# Altium schematic sheet Output.SchDoc
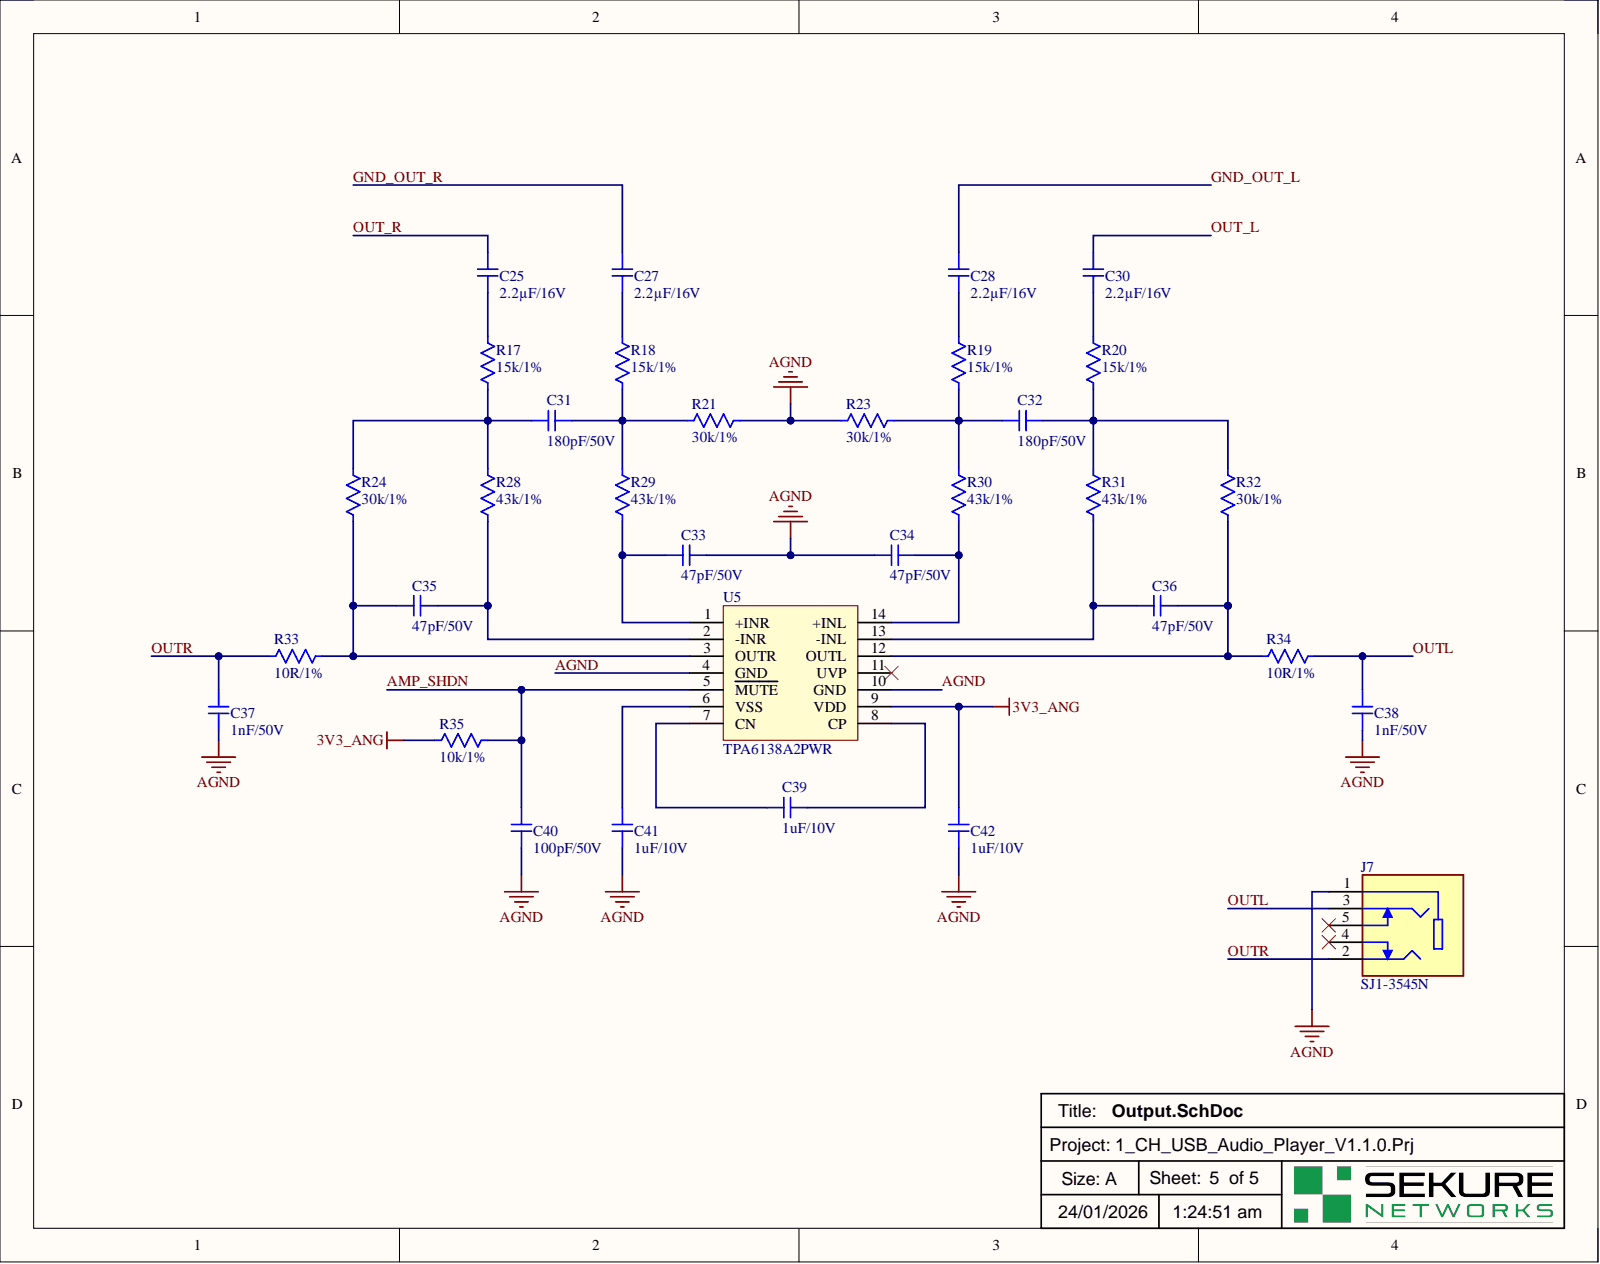
[diagram p0: Output.SchDoc]

## Parts
C25  value 2.2µF/16V  [CAP 0603]  (hidden comment: Ceramic Capacitor)
C27  value 2.2µF/16V  [CAP 0603]  (hidden comment: Ceramic Capacitor)
C28  value 2.2µF/16V  [CAP 0603]  (hidden comment: Ceramic Capacitor)
C30  value 2.2µF/16V  [CAP 0603]  (hidden comment: Ceramic Capacitor)
C31  value 180pF/50V  [CAP 0603]  (hidden comment: Ceramic Capacitor)
C32  value 180pF/50V  [CAP 0603]  (hidden comment: Ceramic Capacitor)
C33  value 47pF/50V  [CAP 0603]  (hidden comment: Ceramic Capacitor)
C34  value 47pF/50V  [CAP 0603]  (hidden comment: Ceramic Capacitor)
C35  value 47pF/50V  [CAP 0603]  (hidden comment: Ceramic Capacitor)
C36  value 47pF/50V  [CAP 0603]  (hidden comment: Ceramic Capacitor)
C37  value 1nF/50V  [CAP 0603]  (hidden comment: Ceramic Capacitor)
C38  value 1nF/50V  [CAP 0603]  (hidden comment: Ceramic Capacitor)
C39  value 1uF/10V  [CAP 0603]  (hidden comment: Ceramic Capacitor)
C40  value 100pF/50V  [CAP 0603]  (hidden comment: Ceramic Capacitor)
C41  value 1uF/10V  [CAP 0603]  (hidden comment: Ceramic Capacitor)
C42  value 1uF/10V  [CAP 0603]  (hidden comment: Ceramic Capacitor)
J7  value SJ1-3545N  [SJ1-3545N]  (hidden comment: Connector)
R17  value 15k/1%  [RES 0603]  (hidden comment: Resistor)
R18  value 15k/1%  [RES 0603]  (hidden comment: Resistor)
R19  value 15k/1%  [RES 0603]  (hidden comment: Resistor)
R20  value 15k/1%  [RES 0603]  (hidden comment: Resistor)
R21  value 30k/1%  [RES 0603]  (hidden comment: Resistor)
R23  value 30k/1%  [RES 0603]  (hidden comment: Resistor)
R24  value 30k/1%  [RES 0603]  (hidden comment: Resistor)
R28  value 43k/1%  [RES 0603]  (hidden comment: Resistor)
R29  value 43k/1%  [RES 0603]  (hidden comment: Resistor)
R30  value 43k/1%  [RES 0603]  (hidden comment: Resistor)
R31  value 43k/1%  [RES 0603]  (hidden comment: Resistor)
R32  value 30k/1%  [RES 0603]  (hidden comment: Resistor)
R33  value 10R/1%  [RES 0603]  (hidden comment: Resistor)
R34  value 10R/1%  [RES 0603]  (hidden comment: Resistor)
R35  value 10k/1%  [RES 0603]  (hidden comment: Resistor)
U5  value TPA6138A2PWR  [14-TSSOP]  (hidden comment: IC)

## Nets
3V3_ANG: C42.2 R35.2 U5.9(VDD)
AGND: C33.1 C34.2 C37.1 C38.1 C40.1 C41.1 C42.1 J7.1 R21.1 R23.2 U5.4(GND) U5.10(GND)
AMP_SHDN: C40.2 R35.1 U5.5(MUTE)
GND_OUT_L: C28.2
GND_OUT_R: C27.2
OUT_L: C30.2
OUT_R: C25.2
OUTL: C38.2 J7.3 R34.2
OUTR: C37.2 J7.2 R33.1
NetC25_1: C25.1 R17.1
NetC27_1: C27.1 R18.1
NetC28_1: C28.1 R19.1
NetC30_1: C30.1 R20.1
NetC31_1: C31.1 R17.2 R24.1 R28.1
NetC31_2: C31.2 R18.2 R21.2 R29.1
NetC32_1: C32.1 R20.2 R31.1 R32.1
NetC32_2: C32.2 R19.2 R23.1 R30.1
NetC33_2: C33.2 R29.2 U5.1(+INR)
NetC34_1: C34.1 R30.2 U5.14(+INL)
NetC35_1: C35.1 R28.2 U5.2(-INR)
NetC35_2: C35.2 R24.2 R33.2 U5.3(OUTR)
NetC36_1: C36.1 R32.2 R34.1 U5.12(OUTL)
NetC36_2: C36.2 R31.2 U5.13(-INL)
NetC39_1: C39.1 U5.7(CN)
NetC39_2: C39.2 U5.8(CP)
NetC41_2: C41.2 U5.6(VSS)

Not connected: J7 4 5; U5 11(UVP)

## Library data of the parts
C25 C27 C28 C30 = CL10A225KO8NNNC: 16V 2.2uF X5R ±10% 0603 Multilayer Ceramic Capacitors MLCC - SMD/SMT ROHS; Manufacturer: Samsung Electro-Mechanics; Case Code (Imperial): 0603; Case Code (Metric): 1608; Case/Package: 0603; Category: Ceramic Capacitors; China RoHS: Compliant; Component Kind: Standard; ComponentLink1Description: https://datasheet.octopart.com:443/CL10A225KO8NNNC-Samsung-Electro-Mechanics-datasheet-136031763.pdf?src-supplier=IHS; ComponentLink1URL: https://datasheet.octopart.com:443/CL10A225KO8NNNC-Samsung-Electro-Mechanics-datasheet-136031763.pdf?src-supplier=IHS; ComponentLink2Description: https://datasheet.octopart.com/CL10A225KO8NNNC-Samsung-Electro-Mechanics-datasheet-8324245.pdf; ComponentLink2URL: https://datasheet.octopart.com/CL10A225KO8NNNC-Samsung-Electro-Mechanics-datasheet-8324245.pdf; ComponentLink3Description: https://datasheet.octopart.com/CL10A225KO8NNNC-Samsung-Electro-Mechanics-datasheet-97040617.pdf; ComponentLink3URL: https://datasheet.octopart.com/CL10A225KO8NNNC-Samsung-Electro-Mechanics-datasheet-97040617.pdf; ComponentLink4Description: https://datasheet.octopart.com/General-Multilayer-Ceramic-Capacitors-Samsung-datasheet-family-111.pdf; ComponentLink4URL: https://datasheet.octopart.com/General-Multilayer-Ceramic-Capacitors-Samsung-datasheet-family-111.pdf; ComponentLink5Description: https://datasheet.octopart.com:443/CL10A225KO8NNNC-Samsung-Electro-Mechanics-datasheet-110326828.pdf?src-supplier=Future+Electronics; ComponentLink5URL: https://datasheet.octopart.com:443/CL10A225KO8NNNC-Samsung-Electro-Mechanics-datasheet-110326828.pdf?src-supplier=Future+Electronics; ComponentLink6Description: https://datasheet.octopart.com:443/CL10A225KO8NNNC-Samsung-Electro-Mechanics-datasheet-81461797.pdf?src-supplier=Future+Electronics; ComponentLink6URL: https://datasheet.octopart.com:443/CL10A225KO8NNNC-Samsung-Electro-Mechanics-datasheet-81461797.pdf?src-supplier=Future+Electronics; ComponentLink7Description: https://datasheet.octopart.com:443/CL10A225KO8NNNC-Samsung-datasheet-48647245.pdf?src-supplier=Future+Electronics; ComponentLink7URL: https://datasheet.octopart.com:443/CL10A225KO8NNNC-Samsung-datasheet-48647245.pdf?src-supplier=Future+Electronics; ComponentLink8Description: https://datasheet.octopart.com:443/CL10A225KO8NNNC-Samsung-datasheet-26589124.pdf?src-supplier=Future+Electronics; ComponentLink8URL: https://datasheet.octopart.com:443/CL10A225KO8NNNC-Samsung-datasheet-26589124.pdf?src-supplier=Future+Electronics; ComponentLink9Description: https://datasheet.octopart.com:443/CL10A225KO8NNNC-Samsung-Electro-Mechanics-datasheet-149156492.pdf?src-supplier=Future+Electronics; ComponentLink9URL: https://datasheet.octopart.com:443/CL10A225KO8NNNC-Samsung-Electro-Mechanics-datasheet-149156492.pdf?src-supplier=Future+Electronics; ComponentLink10Description: https://datasheet.octopart.com:443/CL10A225KO8NNNC-Samsung-Electro-Mechanics-datasheet-11768396.pdf?src-supplier=Arrow+Electronics; ComponentLink10URL: https://datasheet.octopart.com:443/CL10A225KO8NNNC-Samsung-Electro-Mechanics-datasheet-11768396.pdf?src-supplier=Arrow+Electronics; ComponentLink11Description: https://datasheet.octopart.com:443/CL10A225KO8NNNC-Samsung-datasheet-59220082.pdf?src-supplier=TME; ComponentLink11URL: https://datasheet.octopart.com:443/CL10A225KO8NNNC-Samsung-datasheet-59220082.pdf?src-supplier=TME; ComponentLink12Description: http://sigma.octopart.com:80/rohs_certificate/General-Multilayer-Ceramic-Capacitors-Samsung-family-110.pdf?src-supplier=Compliance+Statements; ComponentLink12URL: http://sigma.octopart.com:80/rohs_certificate/General-Multilayer-Ceramic-Capacitors-Samsung-family-110.pdf?src-supplier=Compliance+Statements; ComponentLink13Description: http://sigma.octopart.com:80/rohs_statement/General-Multilayer-Ceramic-Capacitors-Samsung-family-132.pdf?src-supplier=Compliance+Statements; ComponentLink13URL: http://sigma.octopart.com:80/rohs_statement/General-Multilayer-Ceramic-Capacitors-Samsung-family-132.pdf?src-supplier=Compliance+Statements; ComponentLink14Description: http://sigma.octopart.com:80/reach_statement/General-Multilayer-Ceramic-Capacitors-Samsung-family-133.pdf?src-supplier=Compliance+Statements; ComponentLink14URL: http://sigma.octopart.com:80/reach_statement/General-Multilayer-Ceramic-Capacitors-Samsung-family-133.pdf?src-supplier=Compliance+Statements; Contact Plating: Tin; Depth: 800µm; Dielectric: X5R; Dielectric Material: Ceramic; DigiKey: 1276-1040-1-ND; Height: 900µm; Lead Free: Lead Free; Length: 1.6mm; Library Name: Ceramic Capacitors.IntLib; Lifecycle Status: Production; Material: Ceramic; Max Operating Temperature: 85°C; Min Operating Temperature: -55°C; Mounting Technology: Surface Mount; Number of Terminals: 2; P/N: CL10A225KO8NNNC; Pricing: 1=0.08, 10=0.009, 50=0.0088 (USD); Radiation Hardening: No; REACH SVHC: Yes; RoHS: Compliant; Schedule B: 8532240020, 8532240020|8532240020|8532240020|8532240020|8532240020|8532240020|8532240020|8532240020|8532240020|8532240020|8532240020|8532240020|8532240020|8532240020|8532240020|8532240020|8532240020|8532240020|8532240020|8532240020|8532240020|8532240020|8532240020|85; Stock: 10221; Supplier: DigiKey; Thickness: 889µm; Tolerance: 10%; Voltage: 16V; Voltage Rating: 16V; Voltage Rating (DC): 16V; Width: 800µm
C31 C32 = CL10C181JB81PND: Cap Ceramic 180pF 50V C0G 5% Pad SMD 0603 125C Automotive T/R; Manufacturer: Samsung Electro-Mechanics; Temperature Coefficient: 30ppm/°C; Case Code (Imperial): 0603; Case Code (Metric): 1608; Case/Package: 0603; Category: Ceramic Capacitors; China RoHS: Compliant; Component Kind: Standard; ComponentLink1Description: https://datasheet.octopart.com:443/CL10C181JB81PND-Samsung-Electro-Mechanics-datasheet-176142735.pdf?src-supplier=IHS; ComponentLink1URL: https://datasheet.octopart.com:443/CL10C181JB81PND-Samsung-Electro-Mechanics-datasheet-176142735.pdf?src-supplier=IHS; ComponentLink2Description: https://datasheet.octopart.com:443/CL10C181JB81PND-Samsung-Electro-Mechanics-datasheet-141347352.pdf?src-supplier=Samsung+SCT; ComponentLink2URL: https://datasheet.octopart.com:443/CL10C181JB81PND-Samsung-Electro-Mechanics-datasheet-141347352.pdf?src-supplier=Samsung+SCT; Depth: 800µm; Dielectric: C0G; Dielectric Material: Ceramic; DigiKey: 1276-6452-1-ND; Height: 900µm; Length: 1.6mm; Library Name: Ceramic Capacitors.IntLib; Lifecycle Status: Production; Material: Ceramic; Max Operating Temperature: 125°C; Min Operating Temperature: -55°C; Mounting Technology: Surface Mount; Number of Terminals: 2; P/N: CL10C181JB81PND; Pricing: 1=0.1, 10=0.044, 50=0.03, 100=0.0258, 500=0.01866, 1000=0.01649, 2500=0.01421, 5000=0.01284 (USD); Radiation Hardening: No; Ratings: AEC-Q200; REACH SVHC: Yes; RoHS: Compliant; Schedule B: 8532240020; Stock: 10810; Supplier: DigiKey; Thickness: 889µm; Tolerance: 5%; Voltage: 50V; Voltage Rating: 50V; Voltage Rating (DC): 50V; Width: 787.4µm
C33-C36 = CL10C470JB8NNNC: Multilayer Ceramic Capacitor, 47 pF, 50 V, ± 5%, C0G (NP0), 0603 [1608 Metric]; Manufacturer: Samsung Electro-Mechanics; Temperature Coefficient: 300ppm/°C; Voltage: 50V; Case Code (Imperial): 0603; Case Code (Metric): 1608; Case/Package: 0603; Category: Ceramic Capacitors; China RoHS: Compliant; Component Kind: Standard; ComponentLink1Description: https://datasheet.octopart.com:443/CL10C470JB8NNNC-Samsung-Electro-Mechanics-datasheet-136482436.pdf?src-supplier=Farnell; ComponentLink1URL: https://datasheet.octopart.com:443/CL10C470JB8NNNC-Samsung-Electro-Mechanics-datasheet-136482436.pdf?src-supplier=Farnell; ComponentLink2Description: https://datasheet.octopart.com/CL10C470JB8NNNC-Samsung-Electro-Mechanics-datasheet-8324245.pdf; ComponentLink2URL: https://datasheet.octopart.com/CL10C470JB8NNNC-Samsung-Electro-Mechanics-datasheet-8324245.pdf; ComponentLink3Description: https://datasheet.octopart.com/CL10C470JB8NNNC-Samsung-Electro-Mechanics-datasheet-97040617.pdf; ComponentLink3URL: https://datasheet.octopart.com/CL10C470JB8NNNC-Samsung-Electro-Mechanics-datasheet-97040617.pdf; ComponentLink4Description: https://datasheet.octopart.com:443/CL10C470JB8NNNC-Samsung-Electro-Mechanics-datasheet-110226376.pdf?src-supplier=Future+Electronics; ComponentLink4URL: https://datasheet.octopart.com:443/CL10C470JB8NNNC-Samsung-Electro-Mechanics-datasheet-110226376.pdf?src-supplier=Future+Electronics; ComponentLink5Description: https://datasheet.octopart.com:443/CL10C470JB8NNNC-Samsung-Electro-Mechanics-datasheet-81462059.pdf?src-supplier=Future+Electronics; ComponentLink5URL: https://datasheet.octopart.com:443/CL10C470JB8NNNC-Samsung-Electro-Mechanics-datasheet-81462059.pdf?src-supplier=Future+Electronics; ComponentLink6Description: https://datasheet.octopart.com:443/CL10C470JB8NNNC-Samsung-datasheet-48647380.pdf?src-supplier=Future+Electronics; ComponentLink6URL: https://datasheet.octopart.com:443/CL10C470JB8NNNC-Samsung-datasheet-48647380.pdf?src-supplier=Future+Electronics; ComponentLink7Description: https://datasheet.octopart.com:443/CL10C470JB8NNNC-Samsung-datasheet-26669179.pdf?src-supplier=Future+Electronics; ComponentLink7URL: https://datasheet.octopart.com:443/CL10C470JB8NNNC-Samsung-datasheet-26669179.pdf?src-supplier=Future+Electronics; ComponentLink8Description: https://datasheet.octopart.com:443/CL10C470JB8NNNC-Samsung-Electro-Mechanics-datasheet-149136926.pdf?src-supplier=Future+Electronics; ComponentLink8URL: https://datasheet.octopart.com:443/CL10C470JB8NNNC-Samsung-Electro-Mechanics-datasheet-149136926.pdf?src-supplier=Future+Electronics; ComponentLink9Description: https://datasheet.octopart.com:443/CL10C470JB8NNNC-Samsung-datasheet-8326407.pdf?src-supplier=TME; ComponentLink9URL: https://datasheet.octopart.com:443/CL10C470JB8NNNC-Samsung-datasheet-8326407.pdf?src-supplier=TME; ComponentLink10Description: https://datasheet.octopart.com:443/CL10C470JB8NNNC-Samsung-Electro-Mechanics-datasheet-11541288.pdf?src-supplier=Arrow+Electronics; ComponentLink10URL: https://datasheet.octopart.com:443/CL10C470JB8NNNC-Samsung-Electro-Mechanics-datasheet-11541288.pdf?src-supplier=Arrow+Electronics; Depth: 800µm; Dielectric: C0G; Dielectric Material: Ceramic; DigiKey: 1276-1037-1-ND; Height: 900µm; Lead Free: Lead Free; Length: 1.6mm; Library Name: Ceramic Capacitors.IntLib; Lifecycle Status: Production; Material: Ceramic; Max Operating Temperature: 125°C; Min Operating Temperature: -55°C; Mounting Technology: Surface Mount; Number of Terminals: 2; P/N: CL10C470JB8NNNC; Pricing: 1=0.1, 10=0.034, 50=0.0228, 100=0.0195, 500=0.01394, 1000=0.01225 (USD); Radiation Hardening: No; REACH SVHC: Yes; RoHS: Compliant; Schedule B: 8532240020, 8532240020|8532240020, 8532240020|8532240020|8532240020, 8532240020|8532240020|8532240020|8532240020; Stock: 1779120; Supplier: DigiKey; Thickness: 889µm; Tolerance: 5%; Voltage Rating: 50V; Voltage Rating (DC): 50V; Width: 800µm
C37 C38 = CL10B102KB8NNNC: Ceramic Capacitor, Multilayer, Ceramic, 50V, 10% +Tol, 10% -Tol, X7R, +-15% TC, 1nF, Surface Mount, 0603 inch; Manufacturer: Samsung Electro-Mechanics; Case Code (Imperial): 0603; Case Code (Metric): 1608; Case/Package: 0603; Category: Ceramic Capacitors; China RoHS: Compliant; Component Kind: Standard; ComponentLink1Description: https://datasheet.octopart.com:443/CL10B102KB8NNNC-Samsung-Electro-Mechanics-datasheet-137699842.pdf?src-supplier=IHS; ComponentLink1URL: https://datasheet.octopart.com:443/CL10B102KB8NNNC-Samsung-Electro-Mechanics-datasheet-137699842.pdf?src-supplier=IHS; ComponentLink2Description: https://datasheet.octopart.com/CL10B102KB8NNNC-Samsung-Electro-Mechanics-datasheet-8324245.pdf; ComponentLink2URL: https://datasheet.octopart.com/CL10B102KB8NNNC-Samsung-Electro-Mechanics-datasheet-8324245.pdf; ComponentLink3Description: https://datasheet.octopart.com/CL10B102KB8NNNC-Samsung-Electro-Mechanics-datasheet-97040617.pdf; ComponentLink3URL: https://datasheet.octopart.com/CL10B102KB8NNNC-Samsung-Electro-Mechanics-datasheet-97040617.pdf; ComponentLink4Description: https://datasheet.octopart.com/General-Multilayer-Ceramic-Capacitors-Samsung-datasheet-family-111.pdf; ComponentLink4URL: https://datasheet.octopart.com/General-Multilayer-Ceramic-Capacitors-Samsung-datasheet-family-111.pdf; ComponentLink5Description: https://datasheet.octopart.com:443/CL10B102KB8NNNC-Samsung-Electro-Mechanics-datasheet-127092097.pdf?src-supplier=Future+Electronics; ComponentLink5URL: https://datasheet.octopart.com:443/CL10B102KB8NNNC-Samsung-Electro-Mechanics-datasheet-127092097.pdf?src-supplier=Future+Electronics; ComponentLink6Description: https://datasheet.octopart.com:443/CL10B102KB8NNNC-Samsung-Electro-Mechanics-datasheet-81461821.pdf?src-supplier=Future+Electronics; ComponentLink6URL: https://datasheet.octopart.com:443/CL10B102KB8NNNC-Samsung-Electro-Mechanics-datasheet-81461821.pdf?src-supplier=Future+Electronics; ComponentLink7Description: https://datasheet.octopart.com:443/CL10B102KB8NNNC-Samsung-datasheet-44382877.pdf?src-supplier=Future+Electronics; ComponentLink7URL: https://datasheet.octopart.com:443/CL10B102KB8NNNC-Samsung-datasheet-44382877.pdf?src-supplier=Future+Electronics; ComponentLink8Description: https://datasheet.octopart.com:443/CL10B102KB8NNNC-Samsung-datasheet-26589141.pdf?src-supplier=Future+Electronics; ComponentLink8URL: https://datasheet.octopart.com:443/CL10B102KB8NNNC-Samsung-datasheet-26589141.pdf?src-supplier=Future+Electronics; ComponentLink9Description: https://datasheet.octopart.com:443/CL10B102KB8NNNC-Samsung-Electro-Mechanics-datasheet-149158821.pdf?src-supplier=Future+Electronics; ComponentLink9URL: https://datasheet.octopart.com:443/CL10B102KB8NNNC-Samsung-Electro-Mechanics-datasheet-149158821.pdf?src-supplier=Future+Electronics; ComponentLink10Description: https://datasheet.octopart.com:443/CL10B102KB8NNNC-Samsung-datasheet-8326407.pdf?src-supplier=TME; ComponentLink10URL: https://datasheet.octopart.com:443/CL10B102KB8NNNC-Samsung-datasheet-8326407.pdf?src-supplier=TME; ComponentLink11Description: http://sigma.octopart.com:80/rohs_certificate/General-Multilayer-Ceramic-Capacitors-Samsung-family-110.pdf?src-supplier=Compliance+Statements; ComponentLink11URL: http://sigma.octopart.com:80/rohs_certificate/General-Multilayer-Ceramic-Capacitors-Samsung-family-110.pdf?src-supplier=Compliance+Statements; ComponentLink12Description: http://sigma.octopart.com:80/rohs_statement/General-Multilayer-Ceramic-Capacitors-Samsung-family-132.pdf?src-supplier=Compliance+Statements; ComponentLink12URL: http://sigma.octopart.com:80/rohs_statement/General-Multilayer-Ceramic-Capacitors-Samsung-family-132.pdf?src-supplier=Compliance+Statements; ComponentLink13Description: http://sigma.octopart.com:80/reach_statement/General-Multilayer-Ceramic-Capacitors-Samsung-family-133.pdf?src-supplier=Compliance+Statements; ComponentLink13URL: http://sigma.octopart.com:80/reach_statement/General-Multilayer-Ceramic-Capacitors-Samsung-family-133.pdf?src-supplier=Compliance+Statements; Contact Plating: Tin; Depth: 800µm; Dielectric: X7R; Dielectric Material: Ceramic; DigiKey: 1276-1018-1-ND; Height: 900µm; Lead Free: Lead Free; Length: 1.6mm; Library Name: Ceramic Capacitors.IntLib; Lifecycle Status: Production; Material: Ceramic; Max Operating Temperature: 125°C; Min Operating Temperature: -55°C; Mounting Technology: Surface Mount; Number of Terminals: 2; P/N: CL10B102KB8NNNC; Pricing: 1=0.08, 10=0.004 (USD); Radiation Hardening: No; REACH SVHC: Yes; RoHS: Compliant; Schedule B: 8532240020, 8532240020|8532240020, 8532240020|8532240020|8532240020, 8532240020|8532240020|8532240020|8532240020; Stock: 785625; Supplier: DigiKey; Thickness: 889µm; Tolerance: 10%; Voltage: 50V; Voltage Rating: 50V; Voltage Rating (DC): 50V; Width: 800µm
C39 C41 C42 = CL10B105KP8NNNC: Multilayer Ceramic Capacitor, 1 uF, 10 V, ± 10%, X7R, 0603 [1608 Metric]; Manufacturer: Samsung; Material: 4241ConductorMaterial; Voltage Rating: 10V; Case Code (Imperial): 0603; Case Code (Metric): 1608; Case/Package: 0603; Category: Ceramic Capacitors; Component Kind: Standard; Construction: Flat; Depth: 0.8mm; Dielectric: X7R; DigiKey: 1276-1946-1-ND; Height: 0.8mm; Lead Free: Lead Free; Length: 1.6mm; Library Name: Ceramic Capacitors.IntLib; Max Operating Temperature: 125°C; Min Operating Temperature: -55°C; Mounting Technology: Surface Mount; P/N: CL10B105KP8NNNC; Package Quantity: 1; Packaging: Tape and Reel; Pricing: 1=0.1, 10=0.026, 50=0.0146, 100=0.0114, 500=0.00858, 1000=0.00674 (USD); Radiation Hardening: No; RoHS: Yes; RoHS Compliant: Yes; Series: CL; Stock: 7012743; Supplier: Digikey; Thickness: 0.035inch; Tolerance: 10%; Voltage Rating (DC): 10V; Width: 0.031inch
C40 = CL10C101JB8NNNC: Ceramic Capacitor, Multilayer, Ceramic, 50V, 5% +Tol, 5% -Tol, C0G, 0+-30ppm/Celcius TC, 100pF, Surface Mount, 0603...; Manufacturer: Samsung Electro-Mechanics; Number of Channels: 1; Temperature Coefficient: 30ppm/°C; Case Code (Imperial): 0603; Case Code (Metric): 1608; Case/Package: 0603; Category: Ceramic Capacitors; China RoHS: Compliant; Component Kind: Standard; ComponentLink1Description: https://datasheet.octopart.com:443/CL10C101JB8NNNC-Samsung-Electro-Mechanics-datasheet-136482390.pdf?src-supplier=IHS; ComponentLink1URL: https://datasheet.octopart.com:443/CL10C101JB8NNNC-Samsung-Electro-Mechanics-datasheet-136482390.pdf?src-supplier=IHS; ComponentLink2Description: https://datasheet.octopart.com/CL10C101JB8NNNC-Samsung-Electro-Mechanics-datasheet-8324245.pdf; ComponentLink2URL: https://datasheet.octopart.com/CL10C101JB8NNNC-Samsung-Electro-Mechanics-datasheet-8324245.pdf; ComponentLink3Description: https://datasheet.octopart.com/CL10C101JB8NNNC-Samsung-Electro-Mechanics-datasheet-97040617.pdf; ComponentLink3URL: https://datasheet.octopart.com/CL10C101JB8NNNC-Samsung-Electro-Mechanics-datasheet-97040617.pdf; ComponentLink4Description: https://datasheet.octopart.com/General-Multilayer-Ceramic-Capacitors-Samsung-datasheet-family-111.pdf; ComponentLink4URL: https://datasheet.octopart.com/General-Multilayer-Ceramic-Capacitors-Samsung-datasheet-family-111.pdf; ComponentLink5Description: https://datasheet.octopart.com:443/CL10C101JB8NNNC-Samsung-Electro-Mechanics-datasheet-110326842.pdf?src-supplier=Future+Electronics; ComponentLink5URL: https://datasheet.octopart.com:443/CL10C101JB8NNNC-Samsung-Electro-Mechanics-datasheet-110326842.pdf?src-supplier=Future+Electronics; ComponentLink6Description: https://datasheet.octopart.com:443/CL10C101JB8NNNC-Samsung-Electro-Mechanics-datasheet-81461969.pdf?src-supplier=Future+Electronics; ComponentLink6URL: https://datasheet.octopart.com:443/CL10C101JB8NNNC-Samsung-Electro-Mechanics-datasheet-81461969.pdf?src-supplier=Future+Electronics; ComponentLink7Description: https://datasheet.octopart.com:443/CL10C101JB8NNNC-Samsung-datasheet-12521739.pdf?src-supplier=Future+Electronics; ComponentLink7URL: https://datasheet.octopart.com:443/CL10C101JB8NNNC-Samsung-datasheet-12521739.pdf?src-supplier=Future+Electronics; ComponentLink8Description: https://datasheet.octopart.com:443/CL10C101JB8NNNC-Samsung-datasheet-26669111.pdf?src-supplier=Future+Electronics; ComponentLink8URL: https://datasheet.octopart.com:443/CL10C101JB8NNNC-Samsung-datasheet-26669111.pdf?src-supplier=Future+Electronics; ComponentLink9Description: https://datasheet.octopart.com:443/CL10C101JB8NNNC-Samsung-datasheet-8328827.pdf?src-supplier=Future+Electronics; ComponentLink9URL: https://datasheet.octopart.com:443/CL10C101JB8NNNC-Samsung-datasheet-8328827.pdf?src-supplier=Future+Electronics; ComponentLink10Description: https://datasheet.octopart.com:443/CL10C101JB8NNNC-Samsung-Electro-Mechanics-datasheet-149154131.pdf?src-supplier=Future+Electronics; ComponentLink10URL: https://datasheet.octopart.com:443/CL10C101JB8NNNC-Samsung-Electro-Mechanics-datasheet-149154131.pdf?src-supplier=Future+Electronics; ComponentLink11Description: https://datasheet.octopart.com:443/CL10C101JB8NNNC-Samsung-datasheet-8326407.pdf?src-supplier=TME; ComponentLink11URL: https://datasheet.octopart.com:443/CL10C101JB8NNNC-Samsung-datasheet-8326407.pdf?src-supplier=TME; ComponentLink12Description: http://sigma.octopart.com:80/rohs_certificate/General-Multilayer-Ceramic-Capacitors-Samsung-family-110.pdf?src-supplier=Compliance+Statements; ComponentLink12URL: http://sigma.octopart.com:80/rohs_certificate/General-Multilayer-Ceramic-Capacitors-Samsung-family-110.pdf?src-supplier=Compliance+Statements; ComponentLink13Description: http://sigma.octopart.com:80/rohs_statement/General-Multilayer-Ceramic-Capacitors-Samsung-family-132.pdf?src-supplier=Compliance+Statements; ComponentLink13URL: http://sigma.octopart.com:80/rohs_statement/General-Multilayer-Ceramic-Capacitors-Samsung-family-132.pdf?src-supplier=Compliance+Statements; ComponentLink14Description: http://sigma.octopart.com:80/reach_statement/General-Multilayer-Ceramic-Capacitors-Samsung-family-133.pdf?src-supplier=Compliance+Statements; ComponentLink14URL: http://sigma.octopart.com:80/reach_statement/General-Multilayer-Ceramic-Capacitors-Samsung-family-133.pdf?src-supplier=Compliance+Statements; Contact Plating: Tin; Depth: 800µm; Dielectric: C0G; Dielectric Material: Ceramic; DigiKey: 1276-1008-1-ND; Height: 900µm; Lead Free: Lead Free; Length: 1.6mm; Library Name: Ceramic Capacitors.IntLib; Lifecycle Status: Production; Material: Ceramic; Max Operating Temperature: 125°C; Min Operating Temperature: -55°C; Mounting Technology: Surface Mount; Number of Terminals: 2; P/N: CL10C101JB8NNNC; Pricing: 1=0.08, 10=0.005, 50=0.0044, 100=0.004, 500=0.00374 (USD); Radiation Hardening: No; REACH SVHC: Yes; RoHS: Compliant; Schedule B: 8532240020, 8532240020|8532240020, 8532240020|8532240020|8532240020, 8532240020|8532240020|8532240020|8532240020; Stock: 1051756; Supplier: DigiKey; Thickness: 889µm; Tolerance: 5%; Voltage: 50V; Voltage Rating: 50V; Voltage Rating (DC): 50V; Width: 800µm
J7 = SJ1-3545N: 3.5 mm, Stereo, Right Angle, Through Hole, Kinked Pins, Audio Jack Connector; Manufacturer: CUI Devices; Body Color: Black; Body Material: Brass; Gender: Female; Shielding: Unshielded; Category: Audio / Video Connectors; Component Kind: Standard; ComponentLink1Description: https://datasheet.octopart.com/SJ1-3545N-CUI-datasheet-23290.pdf?src-supplier=Digikey; ComponentLink1URL: https://datasheet.octopart.com/SJ1-3545N-CUI-datasheet-23290.pdf?src-supplier=Digikey; ComponentLink2Description: https://datasheet.octopart.com/SJ1-3545N-CUI-datasheet-15696630.pdf?src-supplier=Future+Electronics; ComponentLink2URL: https://datasheet.octopart.com/SJ1-3545N-CUI-datasheet-15696630.pdf?src-supplier=Future+Electronics; ComponentLink3Description: https://datasheet.octopart.com/SJ1-3545N-CUI-Devices-datasheet-175925702.pdf?src-supplier=Master+Electronics; ComponentLink3URL: https://datasheet.octopart.com/SJ1-3545N-CUI-Devices-datasheet-175925702.pdf?src-supplier=Master+Electronics; Contact Material: Brass; Contact Plating: Nickel,Tin; DigiKey: CP1-3545N-ND; Housing Material: PBT; Library Name: Connectors.IntLib; Max Operating Temperature: 85°C; Min Operating Temperature: -25°C; Mounting Technology: Through Hole; P/N: SJ1-3545N; Pricing: 1=1.22, 10=1.066, 25=1.0208, 50=0.9982, 100=0.9527, 250=0.862, 500=0.79876 (USD); RoHS: Yes; Series: SJ1; Stock: 39405; Supplier: Digikey; Termination: Kinked Pin
R17-R20 = ERJ-3EKF1502V: Res Thick Film 0603 15K Ohm 1% 1/10W ±100ppm/°C SMD Punched Carrier T/R; Manufacturer: Panasonic; Manufacturer Lifecycle Status: ACTIVE; Part Series: Precision Thick Film Chip Resistors; Max Power Dissipation: 100mW; Voltage Rating: 75V; Case Code (Imperial): 0603; Case/Package: 0603; Category: Chip SMD Resistors; China RoHS: Non-Compliant; Component Kind: Standard; ComponentLink1Description: https://datasheet.octopart.com:443/ERJ3EKF1502V-Panasonic-datasheet-182147282.pdf?src-supplier=IHS; ComponentLink1URL: https://datasheet.octopart.com:443/ERJ3EKF1502V-Panasonic-datasheet-182147282.pdf?src-supplier=IHS; ComponentLink2Description: https://datasheet.octopart.com:443/ERJ-3EKF1502V-Panasonic-datasheet-82288794.pdf?src-supplier=Panasonic; ComponentLink2URL: https://datasheet.octopart.com:443/ERJ-3EKF1502V-Panasonic-datasheet-82288794.pdf?src-supplier=Panasonic; ComponentLink3Description: https://datasheet.octopart.com:443/ERJ-3EKF1502V-Panasonic-datasheet-13266541.pdf?src-supplier=Newark; ComponentLink3URL: https://datasheet.octopart.com:443/ERJ-3EKF1502V-Panasonic-datasheet-13266541.pdf?src-supplier=Newark; ComponentLink4Description: https://datasheet.octopart.com:443/ERJ-3EKF1502V-Panasonic-datasheet-5313456.pdf?src-supplier=Newark; ComponentLink4URL: https://datasheet.octopart.com:443/ERJ-3EKF1502V-Panasonic-datasheet-5313456.pdf?src-supplier=Newark; ComponentLink5Description: https://datasheet.octopart.com:443/ERJ-3EKF1502V-Panasonic-datasheet-48891056.pdf?src-supplier=Newark; ComponentLink5URL: https://datasheet.octopart.com:443/ERJ-3EKF1502V-Panasonic-datasheet-48891056.pdf?src-supplier=Newark; ComponentLink6Description: https://datasheet.octopart.com:443/ERJ3EKF1502V-Panasonic-datasheet-168681906.pdf?src-supplier=Newark; ComponentLink6URL: https://datasheet.octopart.com:443/ERJ3EKF1502V-Panasonic-datasheet-168681906.pdf?src-supplier=Newark; ComponentLink7Description: https://datasheet.octopart.com:443/ERJ-3EKF1502V-Panasonic-datasheet-136608610.pdf?src-supplier=Burklin+Elektronik; ComponentLink7URL: https://datasheet.octopart.com:443/ERJ-3EKF1502V-Panasonic-datasheet-136608610.pdf?src-supplier=Burklin+Elektronik; ComponentLink8Description: https://datasheet.octopart.com:443/ERJ-3EKF1502V-Panasonic-datasheet-10025800.pdf?src-supplier=Future+Electronics; ComponentLink8URL: https://datasheet.octopart.com:443/ERJ-3EKF1502V-Panasonic-datasheet-10025800.pdf?src-supplier=Future+Electronics; ComponentLink9Description: https://datasheet.octopart.com:443/ERJ-3EKF1502V-Panasonic-datasheet-8853621.pdf?src-supplier=Future+Electronics; ComponentLink9URL: https://datasheet.octopart.com:443/ERJ-3EKF1502V-Panasonic-datasheet-8853621.pdf?src-supplier=Future+Electronics; ComponentLink10Description: https://datasheet.octopart.com:443/ERJ3EKF1502V-Panasonic-datasheet-164353789.pdf?src-supplier=Master+Electronics; ComponentLink10URL: https://datasheet.octopart.com:443/ERJ3EKF1502V-Panasonic-datasheet-164353789.pdf?src-supplier=Master+Electronics; ComponentLink11Description: https://datasheet.octopart.com:443/ERJ-3EKF1502V-Panasonic-datasheet-154766169.pdf?src-supplier=Master+Electronics; ComponentLink11URL: https://datasheet.octopart.com:443/ERJ-3EKF1502V-Panasonic-datasheet-154766169.pdf?src-supplier=Master+Electronics; ComponentLink12Description: https://datasheet.octopart.com:443/ERJ3EKF1502V-Panasonic-datasheet-138819429.pdf?src-supplier=TME; ComponentLink12URL: https://datasheet.octopart.com:443/ERJ3EKF1502V-Panasonic-datasheet-138819429.pdf?src-supplier=TME; ComponentLink13Description: https://datasheet.octopart.com/ERJ-3EKF1502V-Panasonic-datasheet-78375410.pdf; ComponentLink13URL: https://datasheet.octopart.com/ERJ-3EKF1502V-Panasonic-datasheet-78375410.pdf; ComponentLink14Description: https://datasheet.octopart.com:443/ERJ-3EKF1502V-Panasonic-datasheet-11542829.pdf?src-supplier=Arrow+Electronics; ComponentLink14URL: https://datasheet.octopart.com:443/ERJ-3EKF1502V-Panasonic-datasheet-11542829.pdf?src-supplier=Arrow+Electronics; ComponentLink15Description: https://datasheet.octopart.com:443/ERJ-3EKF1502V-Panasonic-datasheet-118621.pdf?src-supplier=DigiKey; ComponentLink15URL: https://datasheet.octopart.com:443/ERJ-3EKF1502V-Panasonic-datasheet-118621.pdf?src-supplier=DigiKey; ComponentLink16Description: https://datasheet.octopart.com:443/ERJ-3EKF1502V-Panasonic-datasheet-41391857.pdf?src-supplier=Electro+Sonic; ComponentLink16URL: https://datasheet.octopart.com:443/ERJ-3EKF1502V-Panasonic-datasheet-41391857.pdf?src-supplier=Electro+Sonic; Composition: Thick Film; Contact Plating: Tin; Depth: 800µm; DigiKey: P15.0KHCT-ND; Features: Automotive AEC-Q200; Height: 450µm; Lead Free: Lead Free; Length: 1.6mm; Library Name: Resistors.IntLib; Lifecycle Status: Production; Max Operating Temperature: 155°C; Military Standard: Not; Min Operating Temperature: -55°C; Mounting Technology: Surface Mount; Number of Terminals: 2; Number of Terminations: 2; P/N: ERJ-3EKF1502V; Packaging: Cut Tape; Pins: 2; Power Dissipation: 100mW; Power Rating: 100mW; Pricing: 1=0.1, 10=0.034, 25=0.0256, 50=0.021, 100=0.0173, 250=0.01364, 500=0.01158, 1000=0.00995 (USD); Radiation Hardening: No; REACH SVHC: Yes; RoHS: Compliant; Schedule B: 8533210045, 8533210045|8533210045|8533210045|8533210045|8533210045|8533210045|8533210045|8533210045|8533210045|8533210045|8533210045|8533210045|8533210045|8533210045|8533210045|8533210045|8533210045|8533210045|8533210045|8533210045|8533210045|8533210045|8533210045|85; Stock: 42518; Supplier: DigiKey; Temperature Coefficient: 100ppm/°C; Termination: SMD/SMT; Tolerance: 1%; Voltage Rating (DC): 75V; Width: 800µm; Working Voltage: 75V
R21 R23 R24 R32 = ERJ-3EKF3002V: Res Thick Film 0603 30K Ohm 1% 0.1W ±100ppm/°C Molded SMD Punched Carrier T/R; Manufacturer: Panasonic; Manufacturer Lifecycle Status: ACTIVE; Part Series: Precision Thick Film Chip Resistors; Max Power Dissipation: 100mW; Voltage Rating: 75V; Case Code (Imperial): 0603; Case Code (Metric): 1608; Case/Package: 0603; Category: Chip SMD Resistors; China RoHS: Non-Compliant; Component Kind: Standard; ComponentLink1Description: https://sigma.octopart.com:443/138766958/technical_drawing/Panasonic-ERJ-3EKF3002V.pdf?src-supplier=Upverter; ComponentLink1URL: https://sigma.octopart.com:443/138766958/technical_drawing/Panasonic-ERJ-3EKF3002V.pdf?src-supplier=Upverter; ComponentLink2Description: https://datasheet.octopart.com:443/ERJ-3EKF3002V-Panasonic-datasheet-82288794.pdf?src-supplier=Panasonic; ComponentLink2URL: https://datasheet.octopart.com:443/ERJ-3EKF3002V-Panasonic-datasheet-82288794.pdf?src-supplier=Panasonic; ComponentLink3Description: https://datasheet.octopart.com:443/ERJ-3EKF3002V-Panasonic-datasheet-13266541.pdf?src-supplier=Farnell; ComponentLink3URL: https://datasheet.octopart.com:443/ERJ-3EKF3002V-Panasonic-datasheet-13266541.pdf?src-supplier=Farnell; ComponentLink4Description: https://datasheet.octopart.com:443/ERJ-3EKF3002V-Panasonic-datasheet-10025800.pdf?src-supplier=Farnell; ComponentLink4URL: https://datasheet.octopart.com:443/ERJ-3EKF3002V-Panasonic-datasheet-10025800.pdf?src-supplier=Farnell; ComponentLink5Description: https://datasheet.octopart.com:443/ERJ-3EKF3002V-Panasonic-datasheet-8853621.pdf?src-supplier=Farnell; ComponentLink5URL: https://datasheet.octopart.com:443/ERJ-3EKF3002V-Panasonic-datasheet-8853621.pdf?src-supplier=Farnell; ComponentLink6Description: https://datasheet.octopart.com:443/ERJ-3EKF3002V-Panasonic-datasheet-136608610.pdf?src-supplier=Farnell; ComponentLink6URL: https://datasheet.octopart.com:443/ERJ-3EKF3002V-Panasonic-datasheet-136608610.pdf?src-supplier=Farnell; ComponentLink7Description: https://datasheet.octopart.com:443/ERJ-3EKF3002V-Panasonic---ECG-datasheet-15994758.pdf?src-supplier=Farnell; ComponentLink7URL: https://datasheet.octopart.com:443/ERJ-3EKF3002V-Panasonic---ECG-datasheet-15994758.pdf?src-supplier=Farnell; ComponentLink8Description: https://datasheet.octopart.com:443/ERJ-3EKF3002V-Panasonic-datasheet-48891056.pdf?src-supplier=Newark; ComponentLink8URL: https://datasheet.octopart.com:443/ERJ-3EKF3002V-Panasonic-datasheet-48891056.pdf?src-supplier=Newark; ComponentLink9Description: https://datasheet.octopart.com:443/ERJ-3EKF3002V-Panasonic-datasheet-5313456.pdf?src-supplier=Newark; ComponentLink9URL: https://datasheet.octopart.com:443/ERJ-3EKF3002V-Panasonic-datasheet-5313456.pdf?src-supplier=Newark; ComponentLink10Description: https://datasheet.octopart.com:443/ERJ3EKF3002V-Panasonic-datasheet-168681906.pdf?src-supplier=Newark; ComponentLink10URL: https://datasheet.octopart.com:443/ERJ3EKF3002V-Panasonic-datasheet-168681906.pdf?src-supplier=Newark; ComponentLink11Description: https://datasheet.octopart.com:443/ERJ-3EKF3002V-Panasonic-datasheet-154766169.pdf?src-supplier=JRH+Electronics; ComponentLink11URL: https://datasheet.octopart.com:443/ERJ-3EKF3002V-Panasonic-datasheet-154766169.pdf?src-supplier=JRH+Electronics; ComponentLink12Description: https://datasheet.octopart.com:443/ERJ3EKF3002V-Panasonic-datasheet-138819429.pdf?src-supplier=Burklin+Elektronik; ComponentLink12URL: https://datasheet.octopart.com:443/ERJ3EKF3002V-Panasonic-datasheet-138819429.pdf?src-supplier=Burklin+Elektronik; ComponentLink13Description: https://datasheet.octopart.com/ERJ-3EKF3002V-Panasonic-datasheet-78375410.pdf; ComponentLink13URL: https://datasheet.octopart.com/ERJ-3EKF3002V-Panasonic-datasheet-78375410.pdf; ComponentLink14Description: https://datasheet.octopart.com:443/ERJ-3EKF3002V-Panasonic-datasheet-11542829.pdf?src-supplier=Arrow+Electronics; ComponentLink14URL: https://datasheet.octopart.com:443/ERJ-3EKF3002V-Panasonic-datasheet-11542829.pdf?src-supplier=Arrow+Electronics; ComponentLink15Description: https://datasheet.octopart.com:443/ERJ-3EKF3002V-Panasonic-datasheet-41391857.pdf?src-supplier=Electro+Sonic; ComponentLink15URL: https://datasheet.octopart.com:443/ERJ-3EKF3002V-Panasonic-datasheet-41391857.pdf?src-supplier=Electro+Sonic; Composition: Thick Film; Contact Plating: Tin; Depth: 800µm; DigiKey: P30.0KHCT-ND; Features: Automotive AEC-Q200; Height: 450µm; Lead Free: Lead Free; Length: 1.6mm; Library Name: Resistors.IntLib; Lifecycle Status: Production; Max Operating Temperature: 155°C; Military Standard: Not; Min Operating Temperature: -55°C; Mounting Technology: Surface Mount; Number of Terminals: 2; Number of Terminations: 2; P/N: ERJ-3EKF3002V; Packaging: Cut Tape; Power Dissipation: 100mW; Power Rating: 100mW; Pricing: 1=0.1, 10=0.034, 25=0.0256, 50=0.021, 100=0.0173, 250=0.01364, 500=0.01158, 1000=0.00995 (USD); Radiation Hardening: No; REACH SVHC: Yes; RoHS: Compliant; Schedule B: 8533210045, 8533210045|8533210045, 8533210045|8533210045|8533210045, 8533210045|8533210045|8533210045|8533210045; Stock: 3465; Supplier: DigiKey; Temperature Coefficient: 100ppm/°C; Termination: SMD/SMT; Tolerance: 1%; Voltage Rating (DC): 75V; Width: 800µm; Working Voltage: 75V
R28-R31 = ERJ-3EKF4302V: Res Thick Film 0603 43K Ohm 1% 1/10W ±100ppm/°C Molded SMD Punched Carrier T/R; Manufacturer: Panasonic; Manufacturer Lifecycle Status: ACTIVE; Part Series: Precision Thick Film Chip Resistors; Max Power Dissipation: 100mW; Voltage Rating: 75V; Case Code (Imperial): 0603; Case Code (Metric): 1608; Case/Package: 0603; Category: Chip SMD Resistors; China RoHS: Non-Compliant; Component Kind: Standard; ComponentLink1Description: https://datasheet.octopart.com:443/ERJ-3EKF4302V-Panasonic-datasheet-82288794.pdf?src-supplier=Panasonic; ComponentLink1URL: https://datasheet.octopart.com:443/ERJ-3EKF4302V-Panasonic-datasheet-82288794.pdf?src-supplier=Panasonic; ComponentLink2Description: https://datasheet.octopart.com:443/ERJ-3EKF4302V-Panasonic-datasheet-48891056.pdf?src-supplier=Newark; ComponentLink2URL: https://datasheet.octopart.com:443/ERJ-3EKF4302V-Panasonic-datasheet-48891056.pdf?src-supplier=Newark; ComponentLink3Description: https://datasheet.octopart.com:443/ERJ-3EKF4302V-Panasonic-datasheet-13266541.pdf?src-supplier=Newark; ComponentLink3URL: https://datasheet.octopart.com:443/ERJ-3EKF4302V-Panasonic-datasheet-13266541.pdf?src-supplier=Newark; ComponentLink4Description: https://datasheet.octopart.com:443/ERJ3EKF4302V-Panasonic-datasheet-168681906.pdf?src-supplier=Newark; ComponentLink4URL: https://datasheet.octopart.com:443/ERJ3EKF4302V-Panasonic-datasheet-168681906.pdf?src-supplier=Newark; ComponentLink5Description: https://datasheet.octopart.com:443/ERJ-3EKF4302V-Panasonic-datasheet-33006990.pdf?src-supplier=Newark; ComponentLink5URL: https://datasheet.octopart.com:443/ERJ-3EKF4302V-Panasonic-datasheet-33006990.pdf?src-supplier=Newark; ComponentLink6Description: https://datasheet.octopart.com:443/ERJ3EKF4302V-Panasonic---ECG-datasheet-21211697.pdf?src-supplier=Newark; ComponentLink6URL: https://datasheet.octopart.com:443/ERJ3EKF4302V-Panasonic---ECG-datasheet-21211697.pdf?src-supplier=Newark; ComponentLink7Description: https://datasheet.octopart.com:443/ERJ-3EKF4302V-Panasonic-datasheet-136608610.pdf?src-supplier=Burklin+Elektronik; ComponentLink7URL: https://datasheet.octopart.com:443/ERJ-3EKF4302V-Panasonic-datasheet-136608610.pdf?src-supplier=Burklin+Elektronik; ComponentLink8Description: https://datasheet.octopart.com:443/ERJ-3EKF4302V-Panasonic-datasheet-154766169.pdf?src-supplier=Master+Electronics; ComponentLink8URL: https://datasheet.octopart.com:443/ERJ-3EKF4302V-Panasonic-datasheet-154766169.pdf?src-supplier=Master+Electronics; ComponentLink9Description: https://datasheet.octopart.com:443/ERJ3EKF4302V-Panasonic-datasheet-138819429.pdf?src-supplier=TME; ComponentLink9URL: https://datasheet.octopart.com:443/ERJ3EKF4302V-Panasonic-datasheet-138819429.pdf?src-supplier=TME; ComponentLink10Description: https://datasheet.octopart.com/ERJ-3EKF4302V-Panasonic-datasheet-78375410.pdf; ComponentLink10URL: https://datasheet.octopart.com/ERJ-3EKF4302V-Panasonic-datasheet-78375410.pdf; ComponentLink11Description: https://datasheet.octopart.com/Precision-Thick-Film-Chip-Resistors-Panasonic-datasheet-family-106.pdf; ComponentLink11URL: https://datasheet.octopart.com/Precision-Thick-Film-Chip-Resistors-Panasonic-datasheet-family-106.pdf; ComponentLink12Description: https://datasheet.octopart.com:443/ERJ3EKF4302V-Panasonic---ECG-datasheet-15994758.pdf?src-supplier=Farnell; ComponentLink12URL: https://datasheet.octopart.com:443/ERJ3EKF4302V-Panasonic---ECG-datasheet-15994758.pdf?src-supplier=Farnell; ComponentLink13Description: https://datasheet.octopart.com:443/ERJ3EKF4302V-Panasonic---ECG-datasheet-10025800.pdf?src-supplier=Farnell; ComponentLink13URL: https://datasheet.octopart.com:443/ERJ3EKF4302V-Panasonic---ECG-datasheet-10025800.pdf?src-supplier=Farnell; ComponentLink14Description: https://datasheet.octopart.com:443/ERJ3EKF4302V-Panasonic---ECG-datasheet-8853621.pdf?src-supplier=Farnell; ComponentLink14URL: https://datasheet.octopart.com:443/ERJ3EKF4302V-Panasonic---ECG-datasheet-8853621.pdf?src-supplier=Farnell; ComponentLink15Description: https://datasheet.octopart.com:443/ERJ3EKF4302V-Panasonic---ECG-datasheet-5313456.pdf?src-supplier=Farnell; ComponentLink15URL: https://datasheet.octopart.com:443/ERJ3EKF4302V-Panasonic---ECG-datasheet-5313456.pdf?src-supplier=Farnell; ComponentLink16Description: https://datasheet.octopart.com:443/ERJ-3EKF4302V-Panasonic-datasheet-41391857.pdf?src-supplier=Electro+Sonic; ComponentLink16URL: https://datasheet.octopart.com:443/ERJ-3EKF4302V-Panasonic-datasheet-41391857.pdf?src-supplier=Electro+Sonic; Composition: Thick Film; Contact Plating: Tin; Depth: 800µm; DigiKey: P43.0KHCT-ND; Features: Automotive AEC-Q200; Height: 450µm; Lead Free: Lead Free; Length: 1.6mm; Library Name: Resistors.IntLib; Lifecycle Status: Production; Max Operating Temperature: 155°C; Military Standard: Not; Min Operating Temperature: -55°C; Mounting Technology: Surface Mount; Number of Terminals: 2; Number of Terminations: 2; P/N: ERJ-3EKF4302V; Packaging: Cut Tape; Power Dissipation: 100mW; Power Rating: 100mW; Pricing: 1=0.1, 10=0.034, 25=0.0256, 50=0.021, 100=0.0173, 250=0.01364, 500=0.01158, 1000=0.00995 (USD); REACH SVHC: Yes; RoHS: Compliant; Schedule B: 8533210045, 8533210045|8533210045, 8533210045|8533210045|8533210045, 8533210045|8533210045|8533210045|8533210045; Stock: 37291; Supplier: DigiKey; Temperature Coefficient: 100ppm/°C; Termination: Solder; Tolerance: 1%; Voltage Rating (DC): 75V; Width: 800µm; Working Voltage: 75V
R33 R34 = ERJ-U03F10R0V: SMD Chip Resistor, 0603 [1608 Metric], 10 ohm, ERJU03 Series, 75 V, Thick Film, 100 mW; Manufacturer: Panasonic; Current Rating: 1A; Power Dissipation: 100mW; Temperature Coefficient: 100ppm/°C; Tolerance: 1%; Voltage Rating: 75V; Case Code (Imperial): 0603; Case Code (Metric): 1608; Case/Package: 0603; Category: Chip SMD Resistors; Component Kind: Standard; ComponentLink1Description: https://datasheet.octopart.com:443/ERJ-U03F10R0V-Panasonic-datasheet-85390793.pdf?src-supplier=Panasonic+SCT; ComponentLink1URL: https://datasheet.octopart.com:443/ERJ-U03F10R0V-Panasonic-datasheet-85390793.pdf?src-supplier=Panasonic+SCT; ComponentLink2Description: https://datasheet.octopart.com:443/ERJ-U03F10R0V-Panasonic-datasheet-61627662.pdf?src-supplier=Farnell; ComponentLink2URL: https://datasheet.octopart.com:443/ERJ-U03F10R0V-Panasonic-datasheet-61627662.pdf?src-supplier=Farnell; ComponentLink3Description: https://datasheet.octopart.com:443/ERJ-U03F10R0V-Panasonic-datasheet-159616995.pdf?src-supplier=Newark; ComponentLink3URL: https://datasheet.octopart.com:443/ERJ-U03F10R0V-Panasonic-datasheet-159616995.pdf?src-supplier=Newark; ComponentLink4Description: https://datasheet.octopart.com:443/ERJ-U03F10R0V-Panasonic-datasheet-11808117.pdf?src-supplier=Newark; ComponentLink4URL: https://datasheet.octopart.com:443/ERJ-U03F10R0V-Panasonic-datasheet-11808117.pdf?src-supplier=Newark; ComponentLink5Description: https://datasheet.octopart.com:443/ERJ-U03F10R0V-Panasonic-datasheet-33006993.pdf?src-supplier=RS+(Formerly+Allied+Electronics); ComponentLink5URL: https://datasheet.octopart.com:443/ERJ-U03F10R0V-Panasonic-datasheet-33006993.pdf?src-supplier=RS+(Formerly+Allied+Electronics); ComponentLink6Description: https://datasheet.octopart.com:443/ERJ-U03F10R0V-Panasonic-datasheet-184398019.pdf?src-supplier=Master+Electronics; ComponentLink6URL: https://datasheet.octopart.com:443/ERJ-U03F10R0V-Panasonic-datasheet-184398019.pdf?src-supplier=Master+Electronics; ComponentLink7Description: https://datasheet.octopart.com:443/ERJU03F10R0V-Panasonic-datasheet-164871545.pdf?src-supplier=Burklin+Elektronik; ComponentLink7URL: https://datasheet.octopart.com:443/ERJU03F10R0V-Panasonic-datasheet-164871545.pdf?src-supplier=Burklin+Elektronik; ComponentLink8Description: https://datasheet.octopart.com/ERJ-U03F10R0V-Panasonic-datasheet-78375410.pdf; ComponentLink8URL: https://datasheet.octopart.com/ERJ-U03F10R0V-Panasonic-datasheet-78375410.pdf; Composition: Thick Film; Contact Plating: Tin; Depth: 800µm; DigiKey: 10-ERJ-U03F10R0VCT-ND; Height: 450µm; Length: 1.6mm; Library Name: Resistors.IntLib; Lifecycle Status: Production; Max Operating Temperature: 155°C; Min Operating Temperature: -55°C; Mounting Technology: Surface Mount; Number of Terminals: 2; P/N: ERJ-U03F10R0V; Packaging: Cut Tape; Power Rating: 100mW; Pricing: 1=0.14, 10=0.066, 25=0.0512, 50=0.043, 100=0.0364, 250=0.0298, 500=0.02602, 1000=0.02304 (USD); REACH SVHC: Yes; RoHS: Compliant; Stock: 7385; Supplier: DigiKey; Termination: SMD/SMT; Voltage Rating (DC): 75V; Weight: 2.012816mg; Width: 800µm; Working Voltage: 75V
R35 = ERJ-3EKF1002V: Res Thick Film 0603 10K Ohm 1% 1/10W ±100ppm/°C Molded SMD Punched Carrier T/R; Manufacturer: Panasonic; Max Power Dissipation: 100mW; Voltage Rating: 75V; Case Code (Imperial): 0603; Case/Package: 0603; Category: Chip SMD Resistors; China RoHS: Non-Compliant; Component Kind: Standard; ComponentLink1Description: https://datasheet.octopart.com:443/ERJ-3EKF1002V-Panasonic-datasheet-13266541.pdf?src-supplier=Newark; ComponentLink1URL: https://datasheet.octopart.com:443/ERJ-3EKF1002V-Panasonic-datasheet-13266541.pdf?src-supplier=Newark; ComponentLink2Description: https://datasheet.octopart.com:443/ERJ-3EKF1002V-Panasonic-datasheet-5313456.pdf?src-supplier=Newark; ComponentLink2URL: https://datasheet.octopart.com:443/ERJ-3EKF1002V-Panasonic-datasheet-5313456.pdf?src-supplier=Newark; ComponentLink3Description: https://datasheet.octopart.com:443/ERJ-3EKF1002V-Panasonic-datasheet-168681906.pdf?src-supplier=Newark; ComponentLink3URL: https://datasheet.octopart.com:443/ERJ-3EKF1002V-Panasonic-datasheet-168681906.pdf?src-supplier=Newark; ComponentLink4Description: https://datasheet.octopart.com:443/ERJ-3EKF1002V-Panasonic-datasheet-48891056.pdf?src-supplier=Farnell; ComponentLink4URL: https://datasheet.octopart.com:443/ERJ-3EKF1002V-Panasonic-datasheet-48891056.pdf?src-supplier=Farnell; ComponentLink5Description: https://datasheet.octopart.com:443/ERJ-3EKF1002V-Panasonic-datasheet-136608610.pdf?src-supplier=Farnell; ComponentLink5URL: https://datasheet.octopart.com:443/ERJ-3EKF1002V-Panasonic-datasheet-136608610.pdf?src-supplier=Farnell; ComponentLink6Description: https://datasheet.octopart.com:443/ERJ-3EKF1002V-Panasonic-datasheet-10025800.pdf?src-supplier=element14+APAC; ComponentLink6URL: https://datasheet.octopart.com:443/ERJ-3EKF1002V-Panasonic-datasheet-10025800.pdf?src-supplier=element14+APAC; ComponentLink7Description: https://datasheet.octopart.com:443/ERJ-3EKF1002V-Panasonic-datasheet-8853621.pdf?src-supplier=element14+APAC; ComponentLink7URL: https://datasheet.octopart.com:443/ERJ-3EKF1002V-Panasonic-datasheet-8853621.pdf?src-supplier=element14+APAC; ComponentLink8Description: https://datasheet.octopart.com:443/ERJ-3EKF1002V-Panasonic-datasheet-82288794.pdf?src-supplier=Future+Electronics; ComponentLink8URL: https://datasheet.octopart.com:443/ERJ-3EKF1002V-Panasonic-datasheet-82288794.pdf?src-supplier=Future+Electronics; ComponentLink9Description: https://datasheet.octopart.com:443/ERJ-3EKF1002V-Panasonic-datasheet-154766169.pdf?src-supplier=Master+Electronics; ComponentLink9URL: https://datasheet.octopart.com:443/ERJ-3EKF1002V-Panasonic-datasheet-154766169.pdf?src-supplier=Master+Electronics; ComponentLink10Description: https://datasheet.octopart.com:443/ERJ3EKF1002V-Panasonic-datasheet-138819429.pdf?src-supplier=Burklin+Elektronik; ComponentLink10URL: https://datasheet.octopart.com:443/ERJ3EKF1002V-Panasonic-datasheet-138819429.pdf?src-supplier=Burklin+Elektronik; ComponentLink11Description: https://datasheet.octopart.com/ERJ-3EKF1002V-Panasonic-datasheet-78375410.pdf; ComponentLink11URL: https://datasheet.octopart.com/ERJ-3EKF1002V-Panasonic-datasheet-78375410.pdf; ComponentLink12Description: https://datasheet.octopart.com/Precision-Thick-Film-Chip-Resistors-Panasonic-datasheet-family-106.pdf; ComponentLink12URL: https://datasheet.octopart.com/Precision-Thick-Film-Chip-Resistors-Panasonic-datasheet-family-106.pdf; ComponentLink13Description: https://datasheet.octopart.com:443/ERJ-3EKF1002V-Panasonic-datasheet-11542829.pdf?src-supplier=Arrow+Electronics; ComponentLink13URL: https://datasheet.octopart.com:443/ERJ-3EKF1002V-Panasonic-datasheet-11542829.pdf?src-supplier=Arrow+Electronics; ComponentLink14Description: https://datasheet.octopart.com:443/ERJ-3EKF1002V-Panasonic-datasheet-7538305.pdf?src-supplier=Jameco; ComponentLink14URL: https://datasheet.octopart.com:443/ERJ-3EKF1002V-Panasonic-datasheet-7538305.pdf?src-supplier=Jameco; ComponentLink15Description: https://datasheet.octopart.com:443/ERJ-3EKF1002V-Panasonic-datasheet-118621.pdf?src-supplier=DigiKey; ComponentLink15URL: https://datasheet.octopart.com:443/ERJ-3EKF1002V-Panasonic-datasheet-118621.pdf?src-supplier=DigiKey; ComponentLink16Description: https://datasheet.octopart.com:443/ERJ-3EKF1002V-Panasonic-datasheet-41391857.pdf?src-supplier=Electro+Sonic; ComponentLink16URL: https://datasheet.octopart.com:443/ERJ-3EKF1002V-Panasonic-datasheet-41391857.pdf?src-supplier=Electro+Sonic; Composition: Thick Film; Contact Plating: Tin; Depth: 800µm; DigiKey: P10.0KHCT-ND; Features: Automotive AEC-Q200; Height: 450µm; Length: 1.6mm; Library Name: Resistors.IntLib; Lifecycle Status: Production; Max Operating Temperature: 155°C; Military Standard: Not; Min Operating Temperature: -55°C; Mounting Technology: Surface Mount; Number of Terminals: 2; Number of Terminations: 2; P/N: ERJ-3EKF1002V; Packaging: Cut Tape; Pins: 2; Power Dissipation: 100mW; Power Rating: 100mW; Pricing: 1=0.1, 10=0.034, 25=0.0256, 50=0.021, 100=0.0173, 250=0.01364, 500=0.01158, 1000=0.01021 (USD); Radiation Hardening: No; REACH SVHC: Yes; RoHS: Compliant; Schedule B: 8533210045, 8533210045|8533210045|8533210045|8533210045|8533210045|8533210045|8533210045|8533210045|8533210045|8533210045|8533210045|8533210045|8533210045|8533210045|8533210045|8533210045|8533210045|8533210045|8533210045|8533210045|8533210045|8533210045|8533210045|85; Stock: 1304666; Supplier: DigiKey; Temperature Coefficient: 100ppm/°C; Termination: SMD/SMT; Tolerance: 1%; Voltage Rating (DC): 75V; Width: 800µm; Working Voltage: 75V
U5 = TPA6138A2PWR: Audio Amplifier, 0.25W, 2 Channel(s), 1 Func, PDSO14; Manufacturer: Texas Instruments; Channels: 1; Max Supply Current: 25mA; Max Supply Voltage: 3.6V; Min Supply Voltage: 3V; Number of Channels: 2; Output Power-Nom: 40mW; Package Body Material: Plastic; Package Equivalence Code: TSSOP14,.25; Power Supplies: 3.3V; Qualification Status: Not Qualified; Case/Package: TSSOP; Category: Amplifiers - Audio; Component Kind: Standard; ComponentLink1Description: https://www.ti.com:443/lit/ds/symlink/tpa6138a2.pdf?src-supplier=IHS+Markit; ComponentLink1URL: https://www.ti.com:443/lit/ds/symlink/tpa6138a2.pdf?src-supplier=IHS+Markit; ComponentLink2Description: https://datasheet.ciiva.com/1788/tpa6138a2-1788965.pdf?src-supplier=Digikey; ComponentLink2URL: https://datasheet.ciiva.com/1788/tpa6138a2-1788965.pdf?src-supplier=Digikey; ComponentLink3Description: https://datasheet.ciiva.com/1788/tpa6138a2-1788966.pdf?src-supplier=Digikey; ComponentLink3URL: https://datasheet.ciiva.com/1788/tpa6138a2-1788966.pdf?src-supplier=Digikey; ComponentLink4Description: https://datasheet.ciiva.com/1788/tpa6138a2-1788967.pdf?src-supplier=Digikey; ComponentLink4URL: https://datasheet.ciiva.com/1788/tpa6138a2-1788967.pdf?src-supplier=Digikey; ComponentLink5Description: https://datasheet.ciiva.com/2970/tpa6138a2-2970130.pdf?src-supplier=Avnet; ComponentLink5URL: https://datasheet.ciiva.com/2970/tpa6138a2-2970130.pdf?src-supplier=Avnet; ComponentLink6Description: https://datasheet.ciiva.com/26911/getdatasheetpartid-409525-26911276.pdf?src-supplier=Verical; ComponentLink6URL: https://datasheet.ciiva.com/26911/getdatasheetpartid-409525-26911276.pdf?src-supplier=Verical; Consumer IC Type: AUDIO AMPLIFIER; DigiKey: 296-28248-1-ND; Height - Seated (Max): 1.2mm; JESD-30 Code: R-PDSO-G14; JESD-609 Code: e4; Length: 5mm; Library Name: IC's.IntLib; Max Operating Temperature: 85°C; Min Operating Temperature: -40°C; Moisture Sensitivity Level: 2; P/N: TPA6138A2PWR; Package Shape: Rectangular; Package Style: SMALL OUTLINE, THIN PROFILE, SHRINK PITCHMeter; Peak Reflow Temperature (Cel): 260°C; Pins: 14; Pricing: 1=0.64, 10=0.567, 25=0.5328, 100=0.4347, 250=0.40376, 500=0.34364, 1000=0.3025 (USD); Reach SVHC Compliant: Yes; RoHS: Yes; RoHS Compliant: Yes; Stock: 7868; Supplier: Digikey; Surface Mount: Yes; Temperature Grade: INDUSTRIAL; Terminal Finish: Nickel; Terminal Form: Gull Wing; Terminal Pitch: 0.65mm; Terminal Position: DUAL; Width: 4.4mm
